# Altium schematic sheet GPS.SchDoc(GPS)
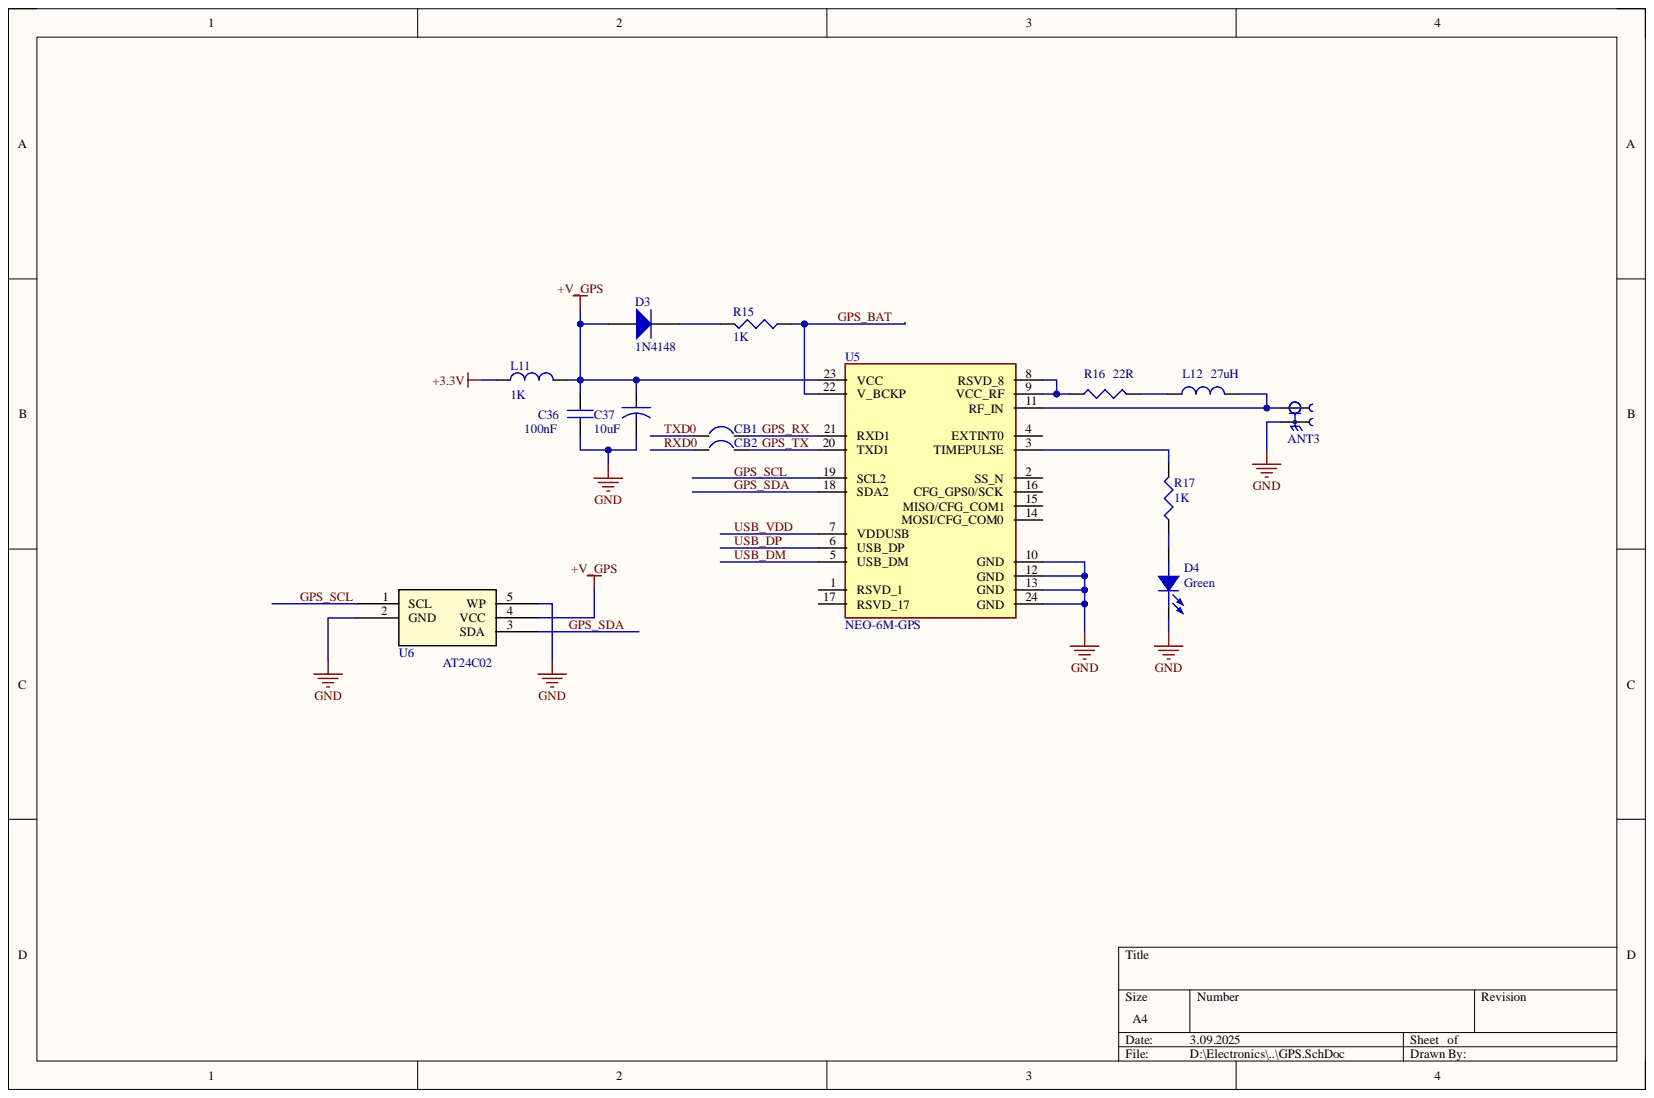
[diagram p0: GPS.SchDoc]

## Parts
ANT3  UFL Antenna  [UFL CONN]  (value not drawn)
C36  value 100nF  [0805 SMD Ceramic Capacitor]  (hidden comment: C0805C104)
C37  value 10uF  [A Case]  (hidden comment: T491A106)
CB1  CircuitBraker  [CircuitBraker]  (value not drawn)
CB2  CircuitBraker  [CircuitBraker]  (value not drawn)
D3  1N4148  [SOD80C]
D4  value Green  [0805 Green LED]  (hidden comment: 0805 Green LED)
L11  value 1K  [0805 Inductor]  (hidden comment: 0805Ferrite)
L12  value 27uH  [0805 Inductor]  (hidden comment: 0805Ferrite)
R15  value 1K  [0805 Resistor]  (hidden comment: 0805_10K)
R16  value 22R  [0805 Resistor]  (hidden comment: 0805_22R%1)
R17  value 1K  [0805 Resistor]  (hidden comment: 0805_10K)
U5  NEO-6M-GPS  [XCVR_NEO-6M-GPS]
U6  value AT24C02  [5TS1_ATM]  (hidden comment: AT24C02D-STUM-T)

## Nets
+3.3V: L11.1
+V_GPS: C36.1 C37.1 D3.2 L11.2 U5.23(VCC) U6.4(VCC)
GND: ANT3.2 C36.2 C37.2 D4.2 U5.10(GND) U5.12(GND) U5.13(GND) U5.24(GND) U6.2(GND) U6.5(WP)
GPS_BAT: R15.1 U5.22(V_BCKP)
GPS_RX: CB1.1 U5.21(RXD1)
GPS_SCL: U5.19(SCL2) U6.1(SCL)
GPS_SDA: U5.18(SDA2) U6.3(SDA)
GPS_TX: CB2.1 U5.20(TXD1)
RXD0: CB2.2
TXD0: CB1.2
USB_DM: U5.5(USB_DM)
USB_DP: U5.6(USB_DP)
USB_VDD: U5.7(VDDUSB)
NetANT3_1: ANT3.1 L12.2 U5.11(RF_IN)
NetD3_1: D3.1 R15.2
NetD4_1: D4.1 R17.1
NetL12_1: L12.1 R16.2
NetR16_1: R16.1 U5.8(RSVD_8) U5.9(VCC_RF)
NetR17_2: R17.2 U5.3(TIMEPULSE)

Not connected: U5 1(RSVD_1) 2(SS_N) 4(EXTINT0) 14(MOSI/CFG_COM0) 15(MISO/CFG_COM1) 16(CFG_GPS0/SCK) 17(RSVD_17)

## Library data of the parts
ANT3 = UFL Antenna: Manufacturer: HIROSE; Manufacturer Code: U.FL-R-SMT-1(10); Component Kind: Standard; Farnell Code: 1688077; Library Name: UFL_CONN.SCHLIB; MOQ: 1; Unit Price: 0,578 €
C36 = C0805C104: Manufacturer: KEMET; Manufacturer Code: C0805C104J5RACTU; Voltage: 50V; Component Kind: Standard; Farnell Code: 1414663; Library Name: Capacitors.SchLib; Package: 0805; Unit Price: 0,06 €
C37 = T491A106: Manufacturer: KEMET; Manufacturer Code: T491A106K006AT; Voltage: 6V3; Component Kind: Standard; Farnell Code: 1457412; Library Name: Capacitors.SchLib; Unit Price: 0,143 €
D3 = 1N4148: Manufacturer: MULTICOMP; Component Kind: Standard; Farnell Code: 9565124; Library Name: 1N4148.SchLib; Manufacturer Code: 1N4148; MOQ: 1; Unit Price: 0,018 €; Value: 1N4148
D4 = 0805 Green LED: Manufacturer: AVAGO Technologies; Manufacturer Code: HSMG-C170; Current: 20mA; Voltage: 2.2V; Component Kind: Standard; Farnell Code: 5790852; Library Name: LED.SchLib; Unit Price: 0,134 €
L11 L12 = 0805Ferrite: Manufacturer: TDK; Manufacturer Code: MMZ2012R102A; Current: 500mA; Component Kind: Standard; Farnell Code: 1669719; Library Name: FerriteBead.SchLib; Unit Price: 0,059 €
R15 R17 = 0805_1K: Manufacturer: MULTICOMP; Manufacturer Code: MC01W080511K; Tolerance: ±1%; Component Kind: Standard; Farnell Code: 9332383; Library Name: Resistors.SchLib; Unit Price: 0,03 €
R16 = 0805_22R%1: Manufacturer: MULTICOMP; Manufacturer Code: MC01W0805122R; Tolerance: ±1%; Component Kind: Standard; Farnell Code: 9332847; Library Name: Resistors.SchLib; MOQ: 10; Unit Price: 0,02 €
U5 = NEO-6M-GPS: GPS receivers featuring the high-performance u-blox 6 positioning engine; Manufacturer Code: NEO-6M-0-001; Value: NEO-6M; Component Kind: Standard; Library Name: NEO-6M-GPS.IntLib; Manufacturer: u-blox; Price: 4; Price Unit: $
U6 = AT24C02D-STUM-T: IC EEPROM 2KBIT I2C 1MHZ SOT23-5; Manufacturer: Microchip; Component Kind: Standard; Digikey Code: AT24C02D-STUM-TCT-ND; Library Name: AT24C02D-STUM-T.IntLib; Manufacturer Code: AT24C02D-STUM-T; Unit Price: 0.21 $
CB1 CB2 = CircuitBraker: Component Kind: Standard; Description: CircuitBraker; Library Name: CircuitBraker.IntLib
